# Altium schematic sheet Sheet 1 - Micro.SchDoc("Sheet 1 - Micro")
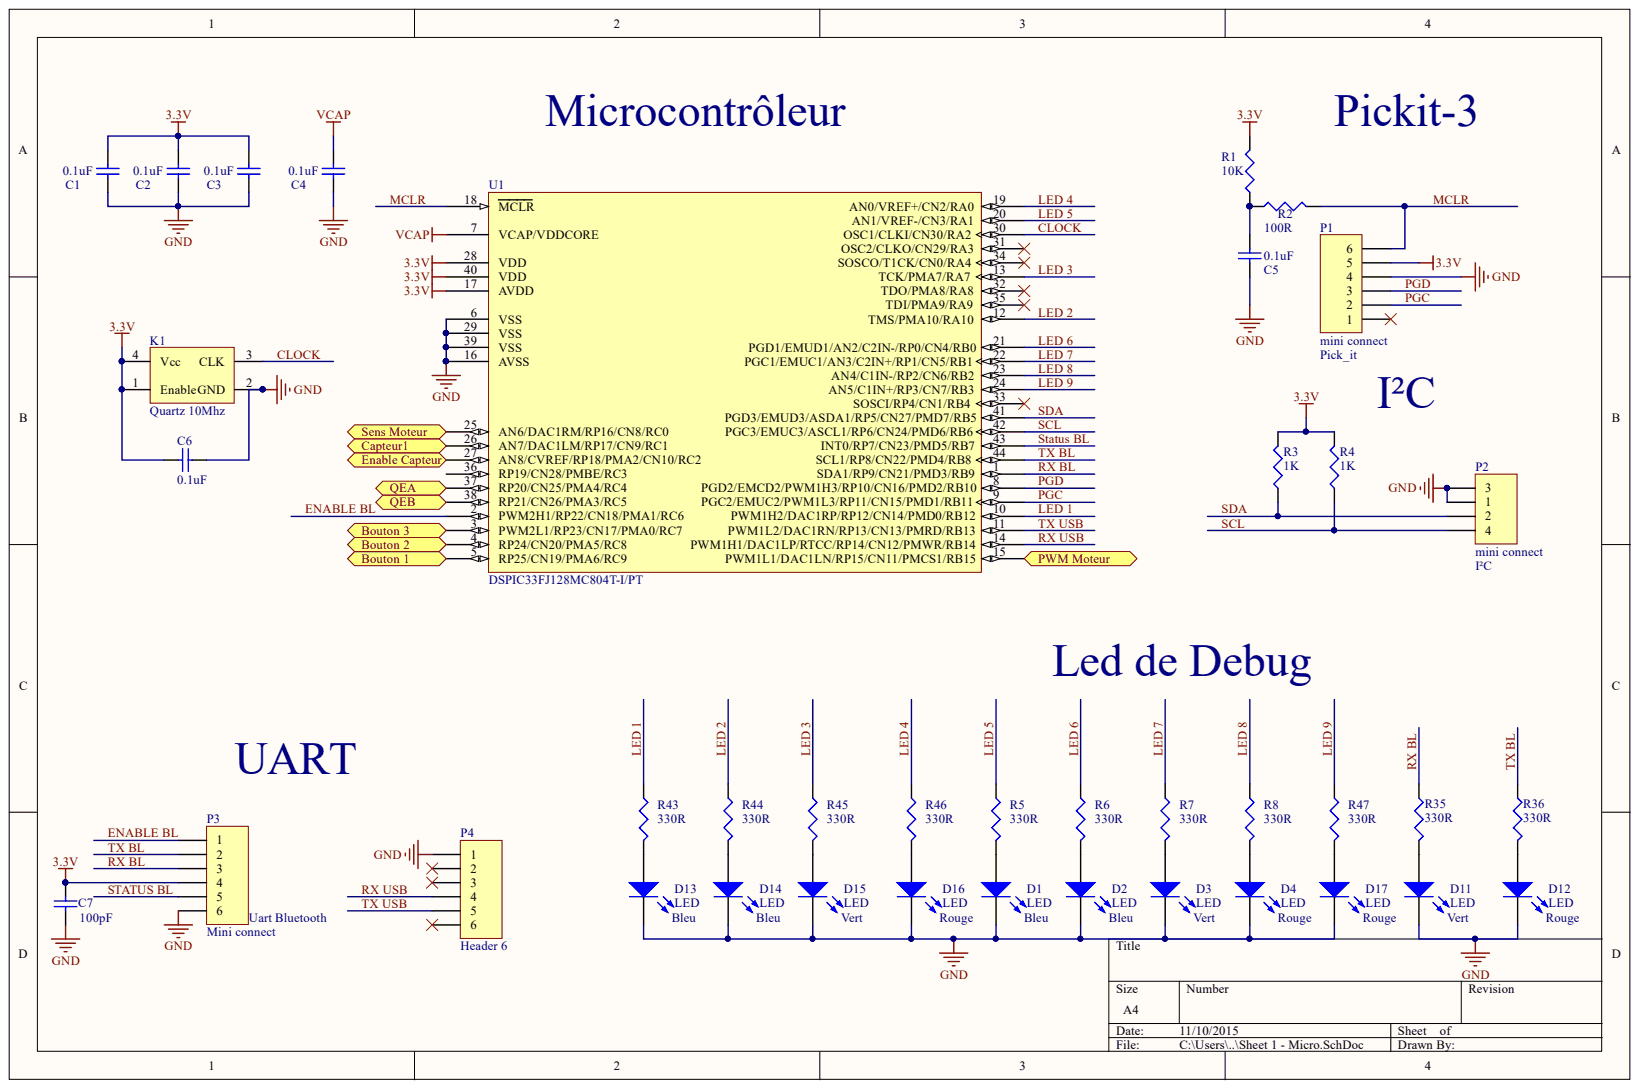
[diagram p0: Sheet 1 - Micro.SchDoc]

## Parts
C1  0.1uF  [C0805]
C2  0.1uF  [C0805]
C3  0.1uF  [C0805]
C4  0.1uF  [C0805]
C5  0.1uF  [C0805]
C6  0.1uF  [C0805]
C7  100pF  [C0805]
D1  LED  value Bleu  [LED 0805]
D2  LED  value Bleu  [LED 0805]
D3  LED  value Vert  [LED 0805]
D4  LED  value Rouge  [LED 0805]
D11  LED  value Vert  [LED 0805]
D12  LED  value Rouge  [LED 0805]
D13  LED  value Bleu  [LED 0805]
D14  LED  value Bleu  [LED 0805]
D15  LED  value Vert  [LED 0805]
D16  LED  value Rouge  [LED 0805]
D17  LED  value Rouge  [LED 0805]
K1  Quartz 10Mhz  value 10Mhz  [IQXO-70]
P1  mini connect  value Pick_it  [690367280676]
P2  mini connect  value I²C  [690367280476]
P3  Mini connect  value Uart Bluetooth  [690367280676]
P4  Header 6  [HDR1X6]
R1  10K  [0805]
R2  100R  [0805]
R3  1K  [0805]
R4  1K  [0805]
R5  330R  [0805]
R6  330R  [0805]
R7  330R  [0805]
R8  330R  [0805]
R35  330R  [0805]
R36  330R  [0805]
R43  330R  [0805]
R44  330R  [0805]
R45  330R  [0805]
R46  330R  [0805]
R47  330R  [0805]
U1  DSPIC33FJ128MC804T-I/PT  [TQFP-PT44_N]

## Ports
BOUTON 1, BOUTON 2, BOUTON 3, CAPTEUR1, ENABLE CAPTEUR, PWM MOTEUR, QEA, QEB, SENS MOTEUR

## Nets
3.3V: C1.2 C2.2 C3.2 C6.2 C7.2 K1.1(Enable) K1.4(Vcc) P1.5 P3.4 R1.2 R3.2 R4.2 U1.17(AVDD) U1.28(VDD) U1.40(VDD)
GND: C1.1 C2.1 C3.1 C4.1 C5.1 C6.1 C7.1 D1.2 D2.2 D3.2 D4.2 D11.2 D12.2 D13.2 D14.2 D15.2 D16.2 D17.2 K1.2(GND) P1.4 P2.1 P2.3 P3.6 P4.1 U1.6(VSS) U1.16(AVSS) U1.29(VSS) U1.39(VSS)
VCAP: C4.2 U1.7(VCAP/VDDCORE)
CLOCK: K1.3(CLK) U1.30(OSC1/CLKI/CN30/RA2)
ENABLE BL: P3.1 U1.2(PWM2H1/RP22/CN18/PMA1/RC6)
LED 1: R43.1 U1.10(PWM1H2/DAC1RP/RP12/CN14/PMD0/RB12)
LED 2: R44.1 U1.12(TMS/PMA10/RA10)
LED 3: R45.1 U1.13(TCK/PMA7/RA7)
LED 4: R46.1 U1.19(AN0/VREF+/CN2/RA0)
LED 5: R5.1 U1.20(AN1/VREF-/CN3/RA1)
LED 6: R6.1 U1.21(PGD1/EMUD1/AN2/C2IN-/RP0/CN4/RB0)
LED 7: R7.1 U1.22(PGC1/EMUC1/AN3/C2IN+/RP1/CN5/RB1)
LED 8: R8.1 U1.23(AN4/C1IN-/RP2/CN6/RB2)
LED 9: R47.1 U1.24(AN5/C1IN+/RP3/CN7/RB3)
MCLR: P1.6 R2.2 U1.18(MCLR)
PGC: P1.2 U1.9(PGC2/EMUC2/PWM1L3/RP11/CN15/PMD1/RB11)
PGD: P1.3 U1.8(PGD2/EMCD2/PWM1H3/RP10/CN16/PMD2/RB10)
QEA: U1.37(RP20/CN25/PMA4/RC4) ; port QEA
QEB: U1.38(RP21/CN26/PMA3/RC5) ; port QEB
RX BL: P3.3 R35.1 U1.1(SDA1/RP9/CN21/PMD3/RB9)
RX USB: P4.4 U1.14(PWM1H1/DAC1LP/RTCC/RP14/CN12/PMWR/RB14)
SCL: P2.4 R4.1 U1.42(PGC3/EMUC3/ASCL1/RP6/CN24/PMD6/RB6)
SDA: P2.2 R3.1 U1.41(PGD3/EMUD3/ASDA1/RP5/CN27/PMD7/RB5)
STATUS BL: P3.5 U1.43(INT0/RP7/CN23/PMD5/RB7)
TX BL: P3.2 R36.1 U1.44(SCL1/RP8/CN22/PMD4/RB8)
TX USB: P4.5 U1.11(PWM1L2/DAC1RN/RP13/CN13/PMRD/RB13)
NetC5_2: C5.2 R1.1 R2.1
NetD1_1: D1.1 R5.2
NetD2_1: D2.1 R6.2
NetD3_1: D3.1 R7.2
NetD4_1: D4.1 R8.2
NetD11_1: D11.1 R35.2
NetD12_1: D12.1 R36.2
NetD13_1: D13.1 R43.2
NetD14_1: D14.1 R44.2
NetD15_1: D15.1 R45.2
NetD16_1: D16.1 R46.2
NetD17_1: D17.1 R47.2
NetU1_3: U1.3(PWM2L1/RP23/CN17/PMA0/RC7) ; port BOUTON 3
NetU1_4: U1.4(RP24/CN20/PMA5/RC8) ; port BOUTON 2
NetU1_5: U1.5(RP25/CN19/PMA6/RC9) ; port BOUTON 1
NetU1_15: U1.15(PWM1L1/DAC1LN/RP15/CN11/PMCS1/RB15) ; port PWM MOTEUR
NetU1_25: U1.25(AN6/DAC1RM/RP16/CN8/RC0) ; port SENS MOTEUR
NetU1_26: U1.26(AN7/DAC1LM/RP17/CN9/RC1) ; port CAPTEUR1
NetU1_27: U1.27(AN8/CVREF/RP18/PMA2/CN10/RC2) ; port ENABLE CAPTEUR

Not connected: P1 1; P4 2 3 6; U1 31(OSC2/CLKO/CN29/RA3) 32(TDO/PMA8/RA8) 33(SOSCI/RP4/CN1/RB4) 34(SOSCO/T1CK/CN0/RA4) 35(TDI/PMA9/RA9) 36(RP19/CN28/PMBE/RC3)

## Library data of the parts
C1-C7 = Cap: Capacitor 0805; Component Kind: Standard; LatestRevisionDate: 17-Jul-2002; LatestRevisionNote: Re-released for DXP Platform.; Library Name: Miscellaneous Devices.IntLib; PackageReference: RAD-0.3; Published: 8-Jun-2000; Signal Integrity: Cap; Simulation: CAP; Publisher: Altium Limited
  C1-C3 C5 C6: value: 0.1uF
  C7: Value: 100pF
D1-D4 D11-D17 = LED2: Typical RED, GREEN, YELLOW, AMBER GaAs LED 0805; Component Kind: Standard; LatestRevisionDate: 17-Jul-2002; LatestRevisionNote: Re-released for DXP Platform.; Library Name: Miscellaneous Devices.IntLib; PackageReference: 3.2X1.6X1.1; PackageVersion: Feb-2002; Published: 8-Jun-2000; Simulation: LED2; Publisher: Altium Limited
K1 = OSCILLATEUR: Oscillateur quartz; part number: 478-8625; Component Kind: Standard; Library Name: lib_peb_1.SchLib
P1 P3 = MHDR1X6: Header, 6-Pin; Component Kind: Standard; LatestRevisionDate: 17-Jul-2002; LatestRevisionNote: Re-released for DXP Platform.; Library Name: Miscellaneous Connectors.IntLib; Signal Integrity: Connector; Publisher: Altium Limited
P2 = MHDR1X4: Header, 4-Pin; Component Kind: Standard; LatestRevisionDate: 17-Jul-2002; LatestRevisionNote: Re-released for DXP Platform.; Library Name: Miscellaneous Connectors.IntLib; Signal Integrity: Connector; Publisher: Altium Limited
P4 = Header 6: Header, 6-Pin; Component Kind: Standard; LatestRevisionDate: 17-Jul-2002; LatestRevisionNote: Re-released for DXP Platform.; Library Name: Miscellaneous Connectors.IntLib; Signal Integrity: Connector; Publisher: Altium Limited
R1-R6 R43 R44 = Res3: Resistor 0805; Component Kind: Standard; LatestRevisionDate: 29-May-2009; LatestRevisionNote: IPC-7351 Footprint Added.; Library Name: Miscellaneous Devices.IntLib; PackageDescription: Chip Resistor; PackageReference: J1-0603; Published: 8-Jun-2000; Signal Integrity: Res; Simulation: RESISTOR; Publisher: Altium Limited
  R1: Value: 10K
  R2: value: 100R
  R3 R4: Value: 1K
  R5 R6 R43 R44: Value: 330R
R7 R8 R35 R36 R45-R47 = Res3: Resistor 0805; Value: 470R; Component Kind: Standard; LatestRevisionDate: 29-May-2009; LatestRevisionNote: IPC-7351 Footprint Added.; Library Name: Miscellaneous Devices.IntLib; PackageDescription: Chip Resistor; PackageReference: J1-0603; Published: 8-Jun-2000; Signal Integrity: Res; Simulation: RESISTOR; Publisher: Altium Limited
U1 = DSPIC33FJ128MC804T-I/PT: High-Performance 16-bit Digital Signal Controller, 128 KB Flash, 16 KB RAM, 44-Pin TQFP, Industrial Temperature, Tape and Reel; Code_JEDEC: MS-026; Component Kind: Standard; ComponentLink1Description: Datasheet; ComponentLink1URL: http://ww1.microchip.com/downloads/en/DeviceDoc/70291D.pdf; ComponentLink2Description: Packaging Specification; ComponentLink2URL: http://www.microchip.com/stellent/groups/techpub_sg/documents/packagingspec/en012702.pdf; ComponentLink3Description: Microchip.com Home; ComponentLink3URL: http://www.microchip.com/; DatasheetVersion: revD, Nov-2009; FirstPublished: 29-Jan-2009; LatestRevisionDate: 02-Dec-2009; LatestRevisionNote: Updated to datasheet revision D, Nov-2009; Library Name: Microchip DSC 16-Bit dsPIC33F.IntLib; PackageDescription: 44-Lead Plastic Thin Quad Flatpack (PT) - 10x10x1mm Body, 2.00mm [TQFP]; PackageReference: TQFP-PT44; PackageVersion: revBB, Aug-2009; Supplier 1: Digi-Key; Supplier 2: Newark; Supplier Part Number 1: DSPIC33FJ128MC804T-I/PTTR-ND; Supplier Part Number 2: 39M8148; Publisher: Altium Limited
